# Altium schematic sheet Memory.SchDoc
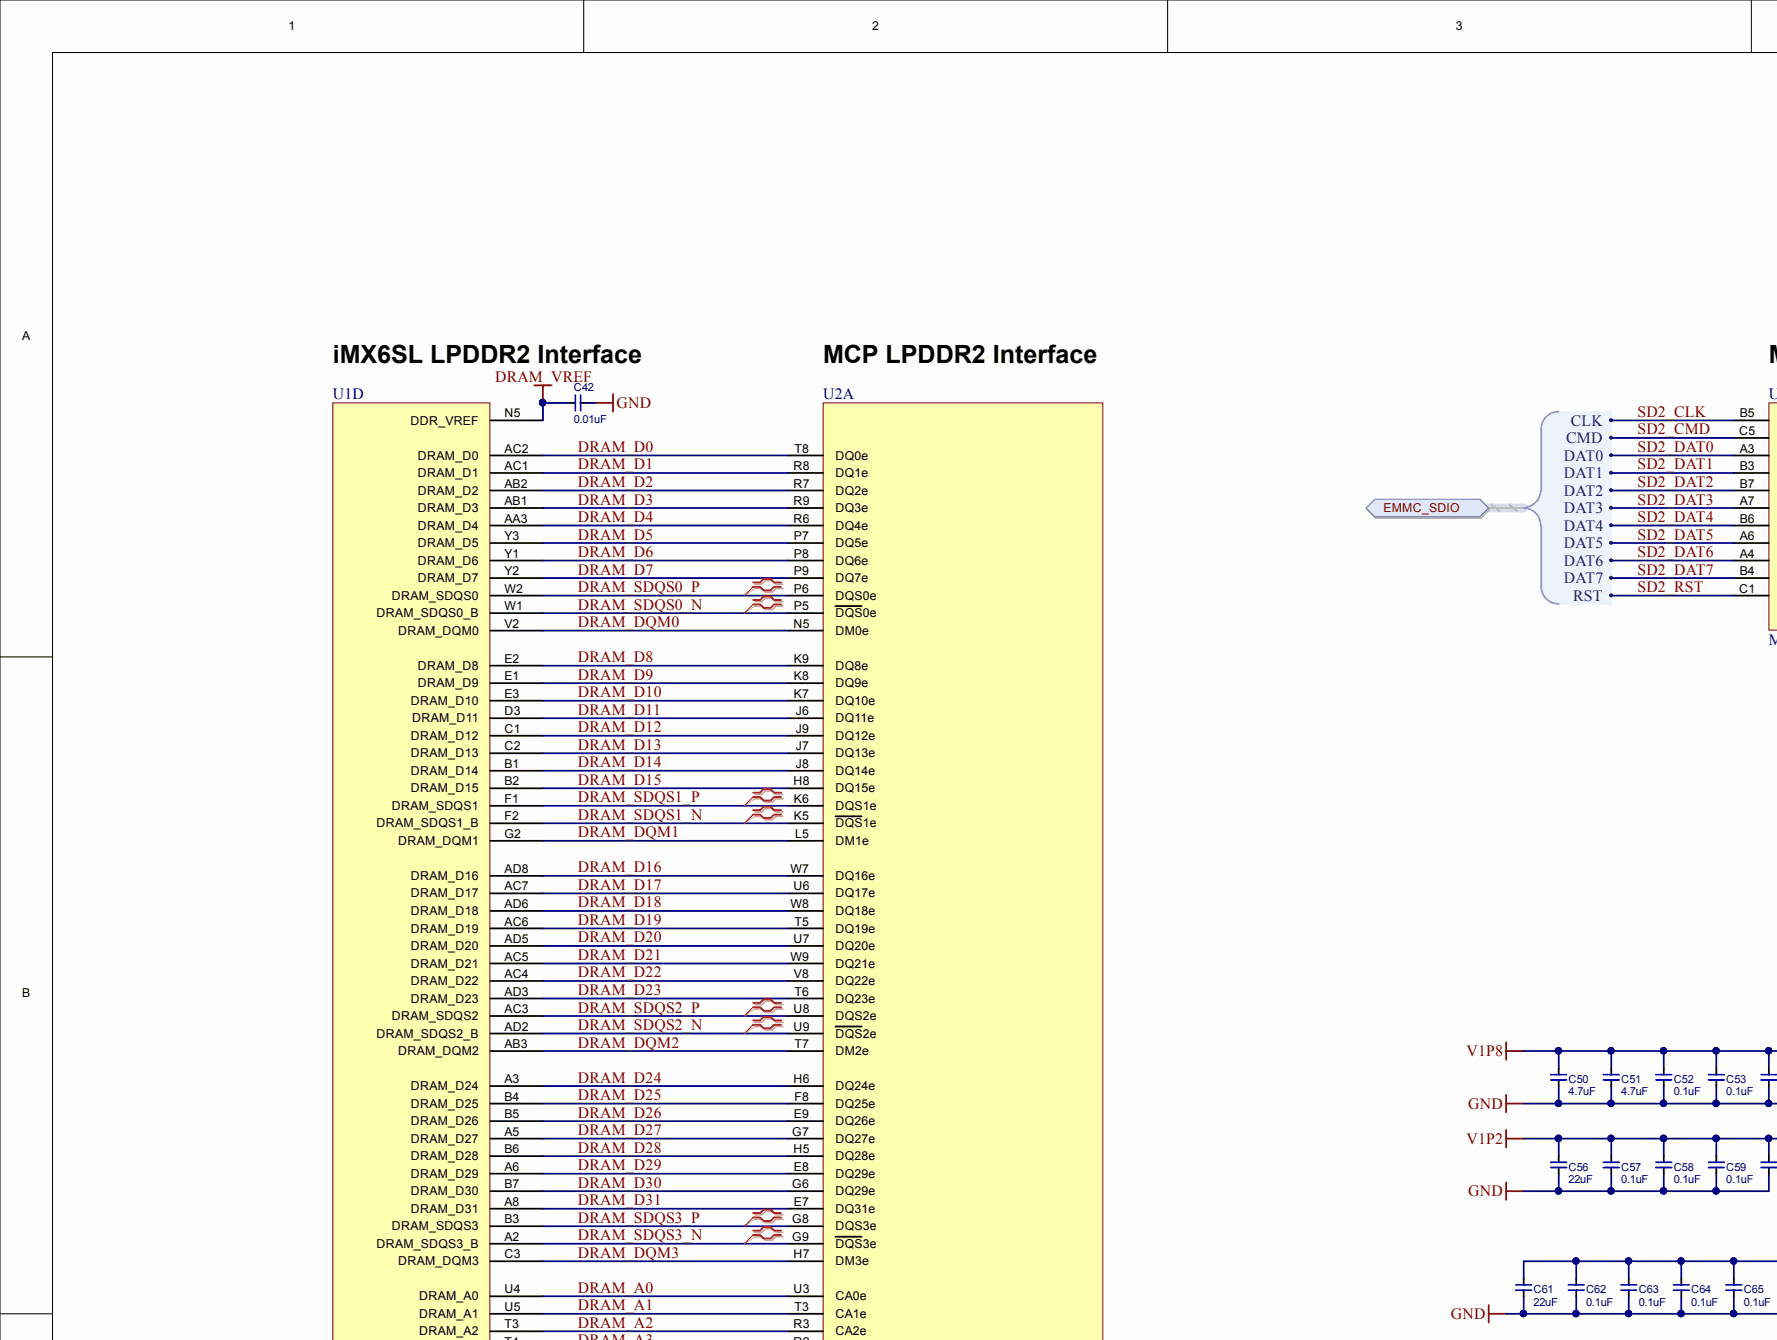
[diagram p0: Memory.SchDoc, top left]
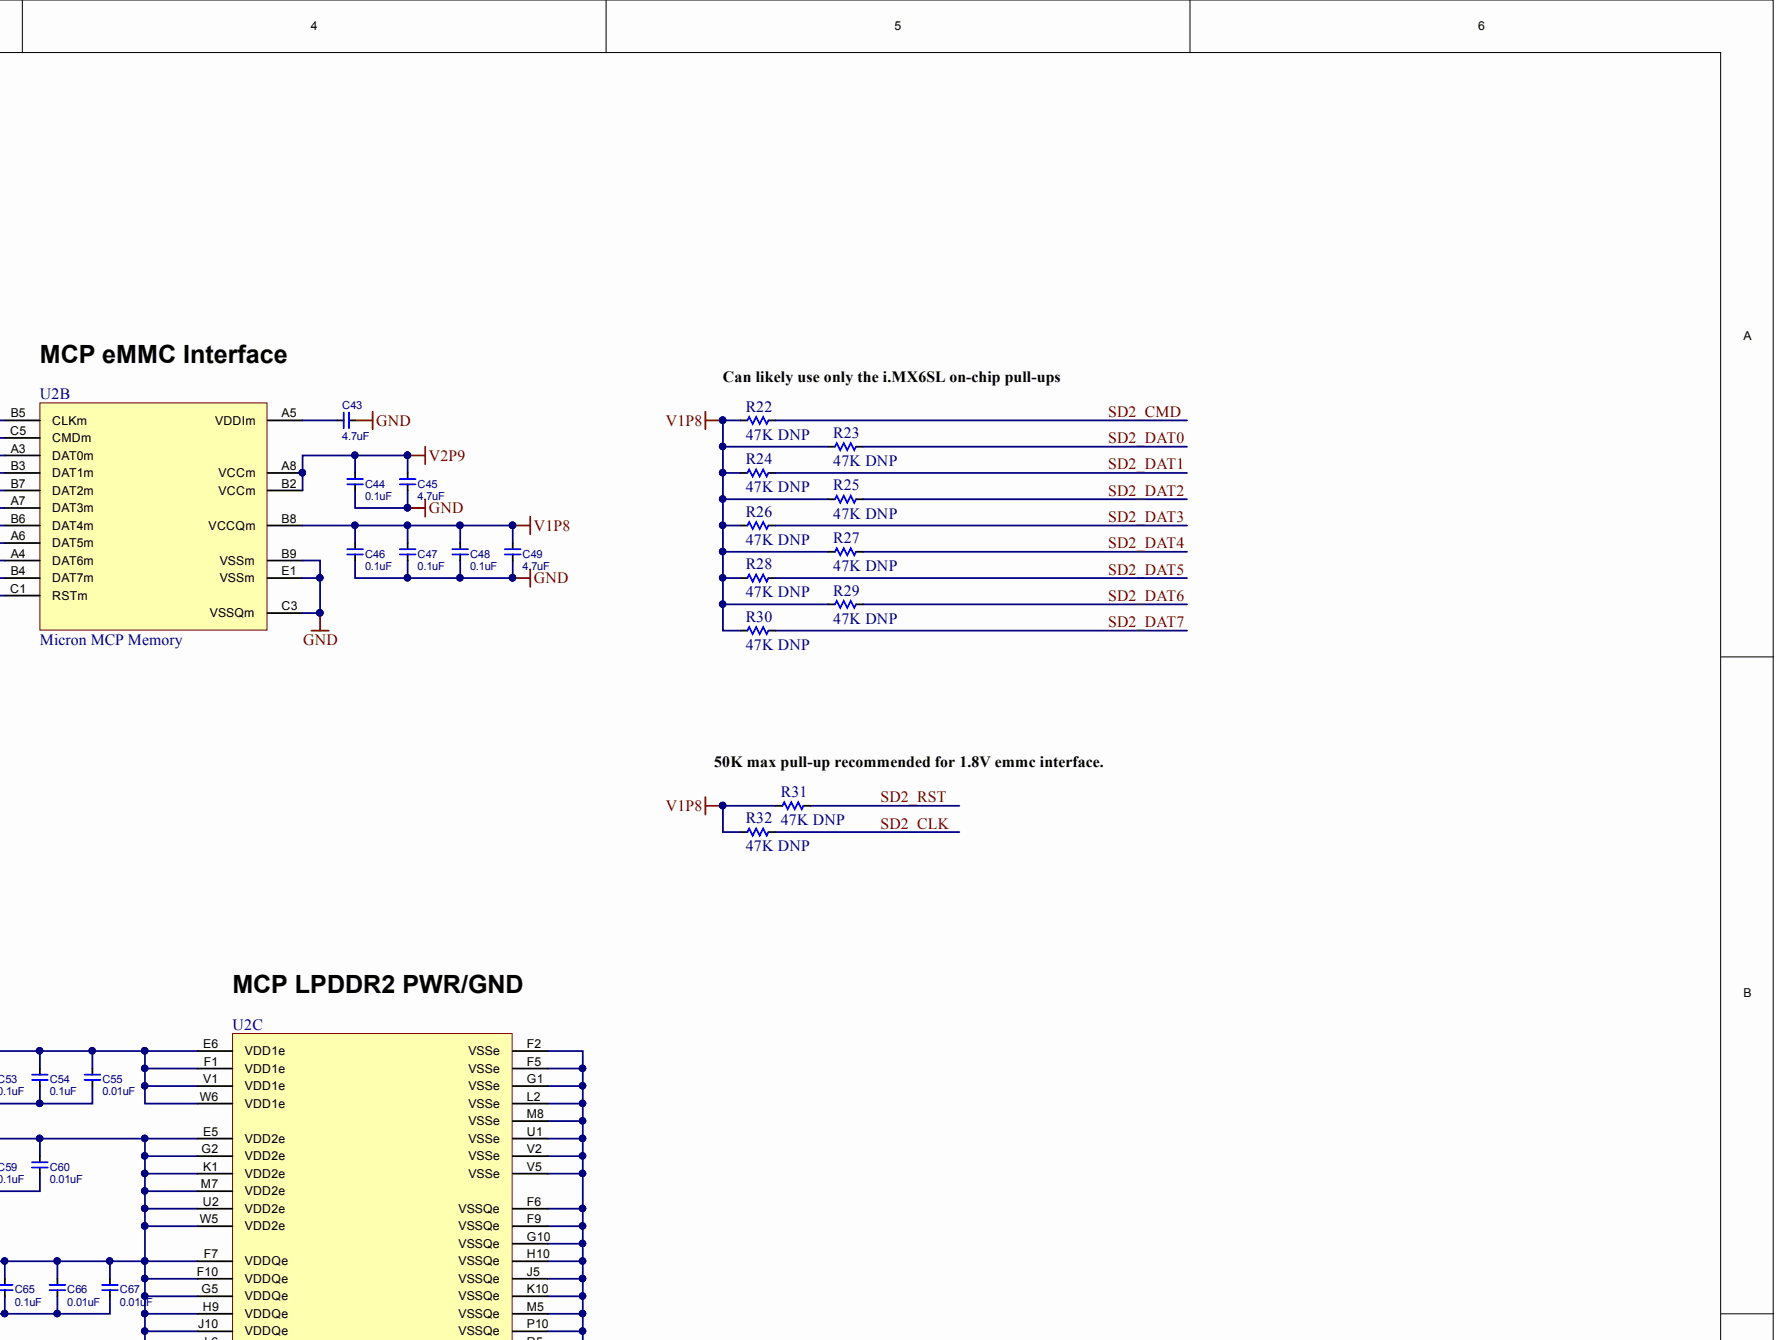
[diagram p1: Memory.SchDoc, top right]
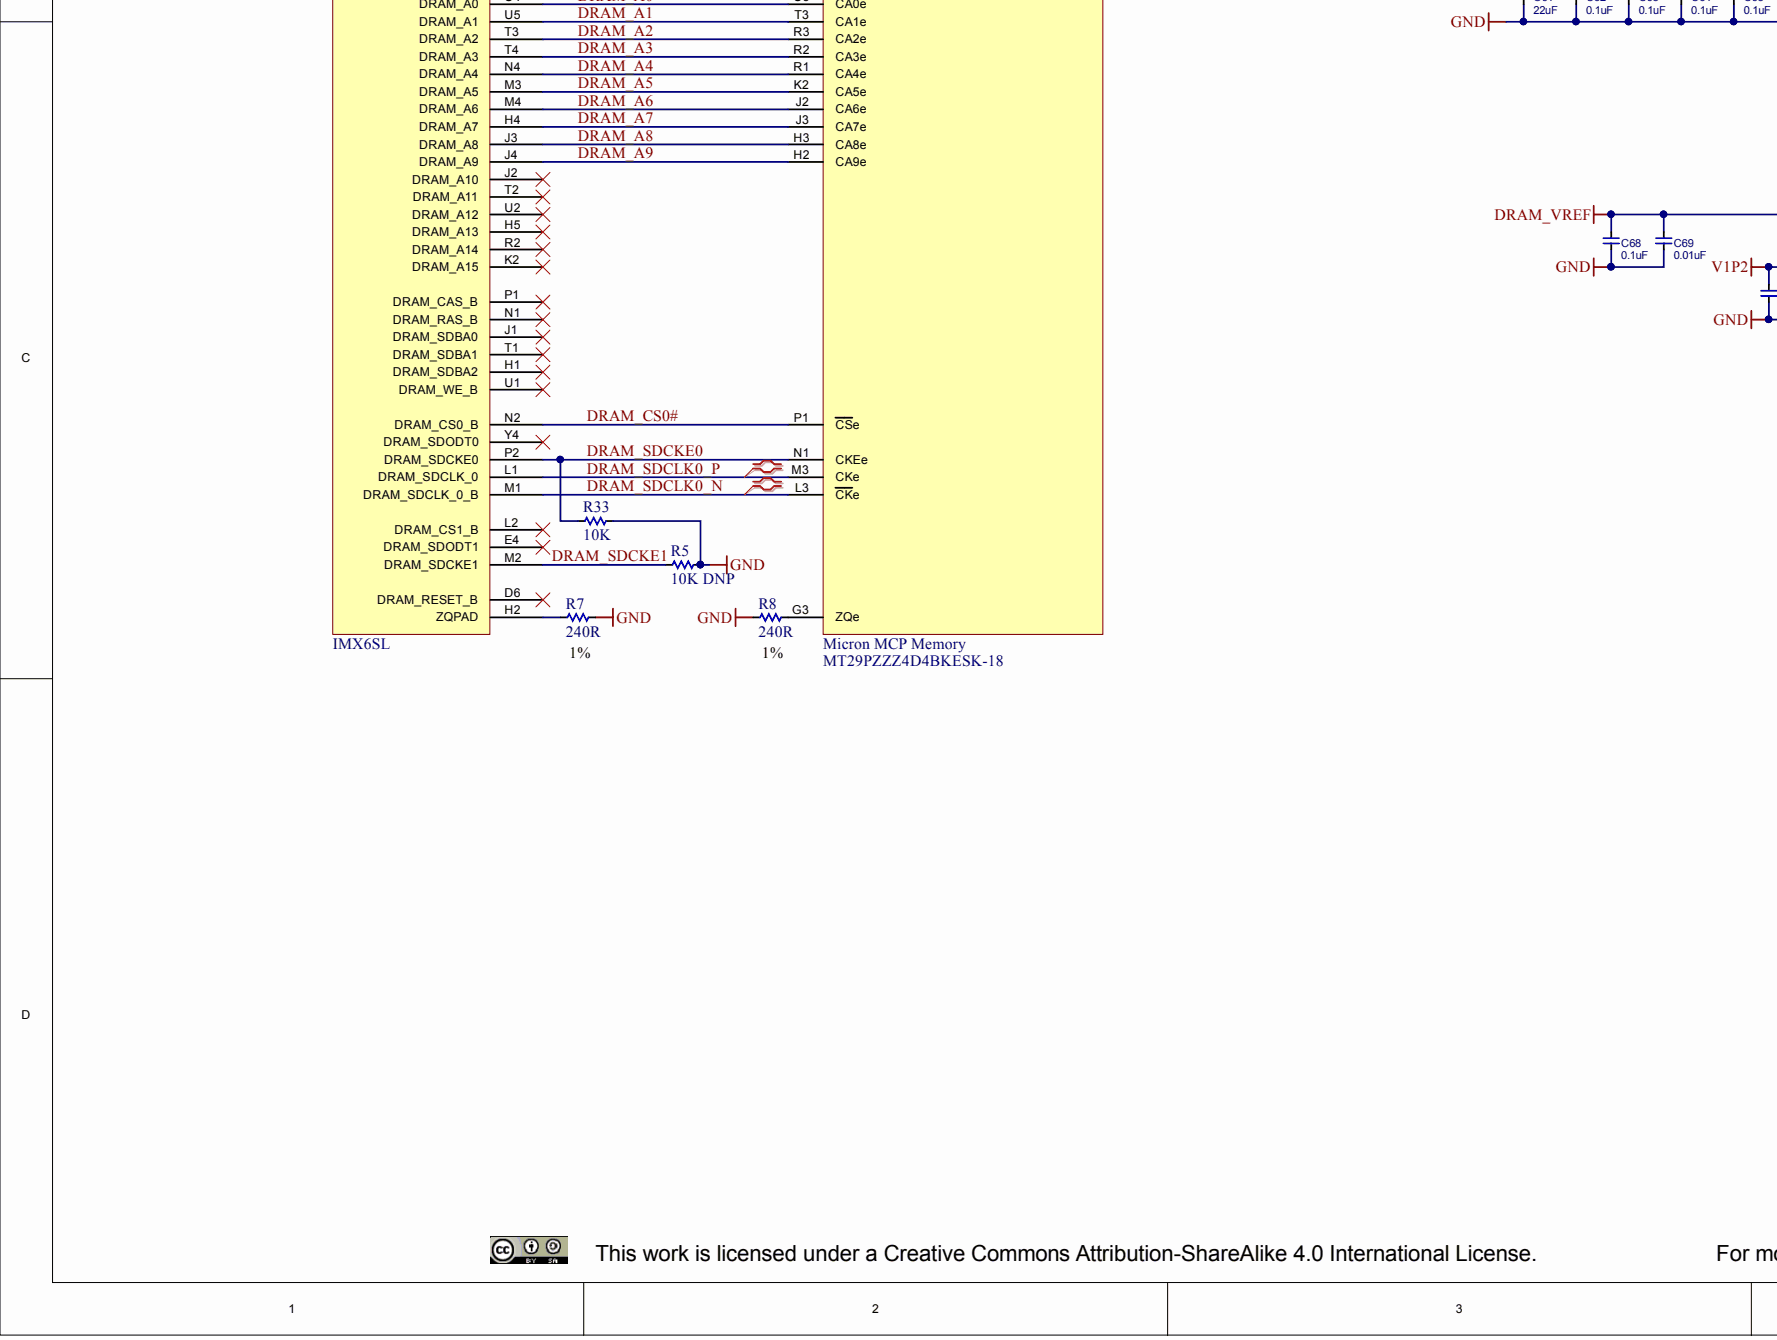
[diagram p2: Memory.SchDoc, bottom left]
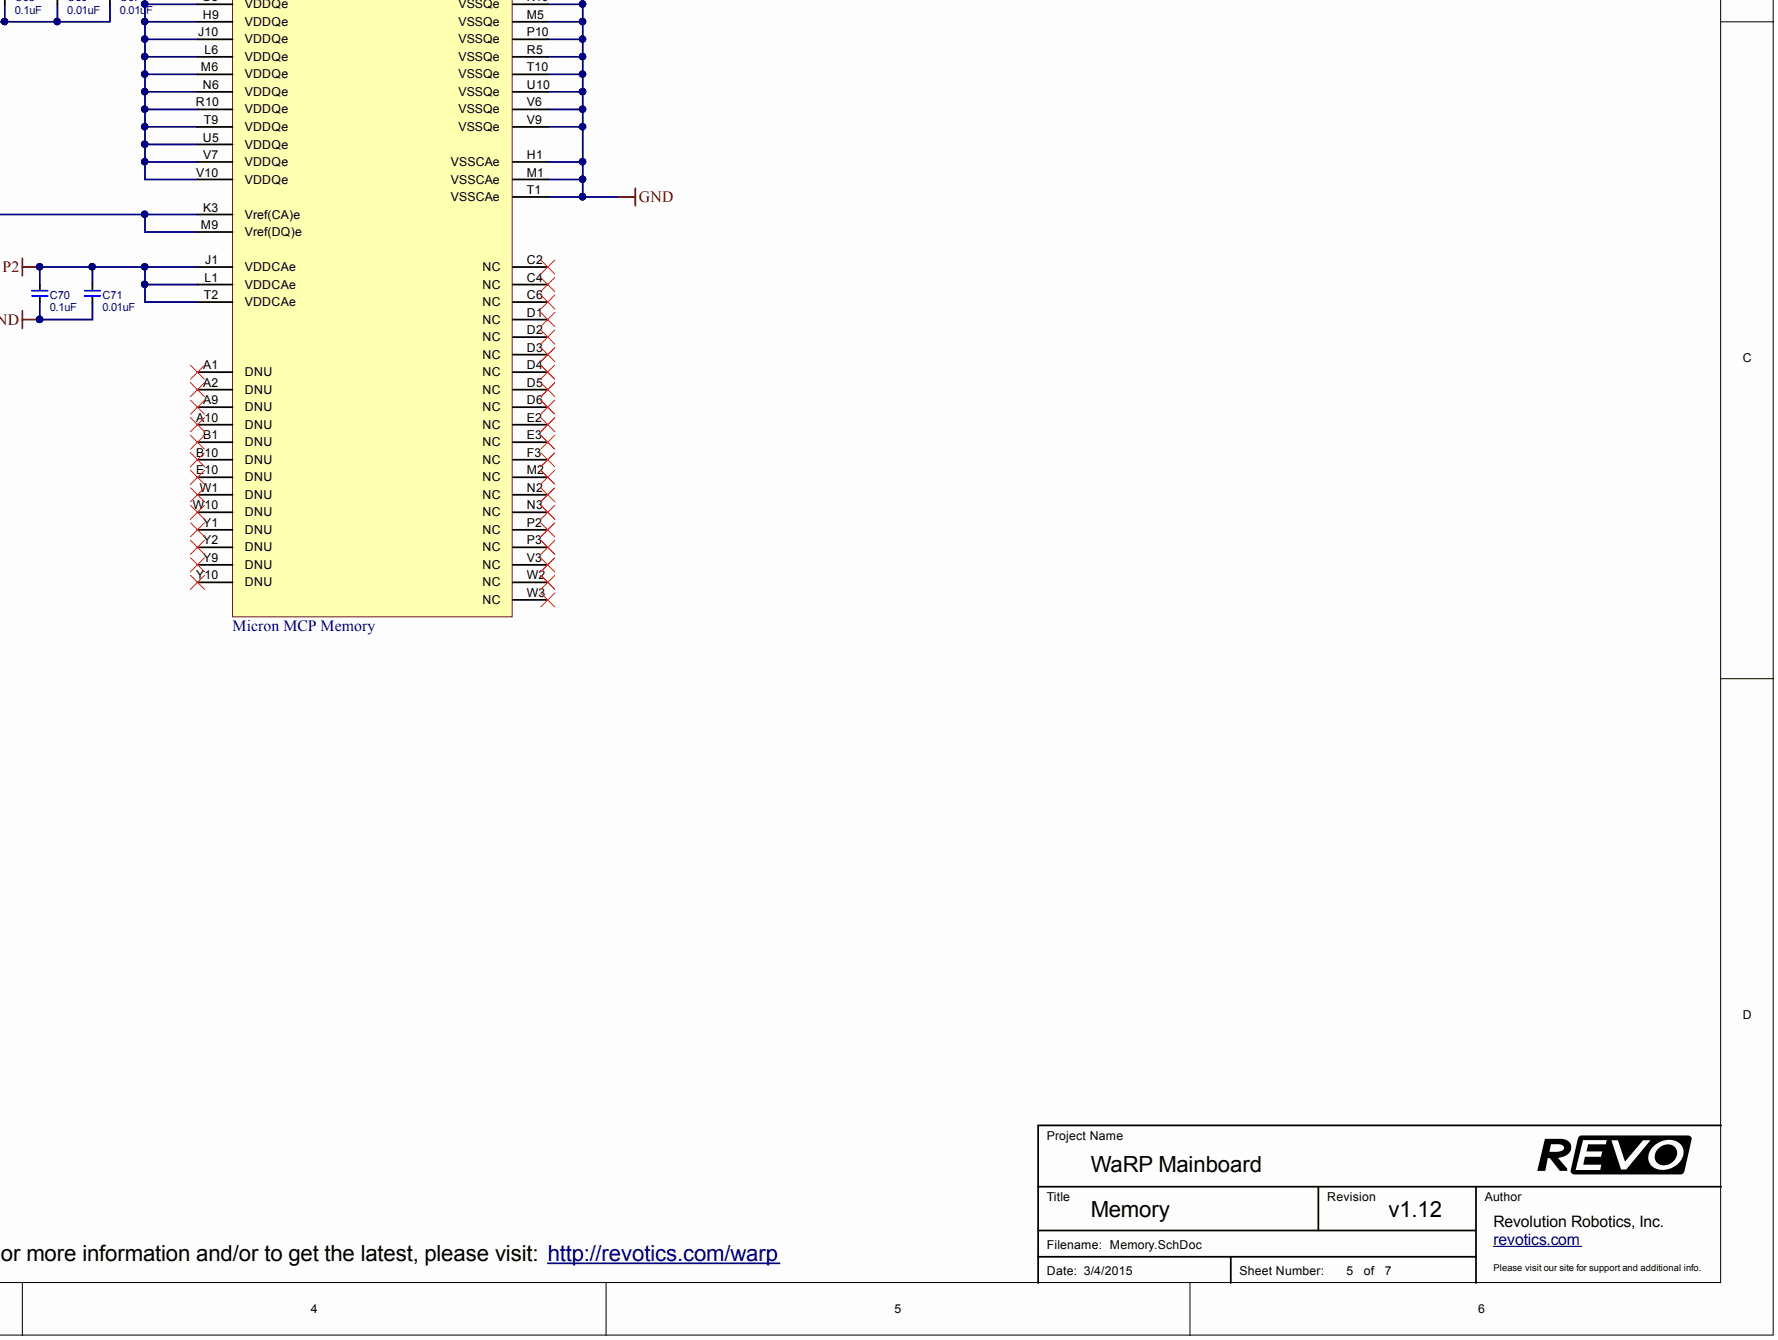
[diagram p3: Memory.SchDoc, bottom right]

## Parts
C42  value 0.01uF  [0201 (0603) Cap High Density]  (hidden comment: CapacitorSM)
C43  value 4.7uF  [0402 (1005) Cap High Density]  (hidden comment: CapacitorSM)
C44  value 0.1uF  [0201 (0603) Cap High Density]  (hidden comment: CapacitorSM)
C45  value 4.7uF  [0402 (1005) Cap High Density]  (hidden comment: CapacitorSM)
C46  value 0.1uF  [0201 (0603) Cap High Density]  (hidden comment: CapacitorSM)
C47  value 0.1uF  [0201 (0603) Cap High Density]  (hidden comment: CapacitorSM)
C48  value 0.1uF  [0201 (0603) Cap High Density]  (hidden comment: CapacitorSM)
C49  value 4.7uF  [0402 (1005) Cap High Density]  (hidden comment: CapacitorSM)
C50  value 4.7uF  [0402 (1005) Cap High Density]  (hidden comment: CapacitorSM)
C51  value 4.7uF  [0402 (1005) Cap High Density]  (hidden comment: CapacitorSM)
C52  value 0.1uF  [0201 (0603) Cap High Density]  (hidden comment: CapacitorSM)
C53  value 0.1uF  [0201 (0603) Cap High Density]  (hidden comment: CapacitorSM)
C54  value 0.1uF  [0201 (0603) Cap High Density]  (hidden comment: CapacitorSM)
C55  value 0.01uF  [0201 (0603) Cap High Density]  (hidden comment: CapacitorSM)
C56  value 22uF  [0603 (1608) Cap High Density]  (hidden comment: CapacitorSM)
C57  value 0.1uF  [0201 (0603) Cap High Density]  (hidden comment: CapacitorSM)
C58  value 0.1uF  [0201 (0603) Cap High Density]  (hidden comment: CapacitorSM)
C59  value 0.1uF  [0201 (0603) Cap High Density]  (hidden comment: CapacitorSM)
C60  value 0.01uF  [0201 (0603) Cap High Density]  (hidden comment: CapacitorSM)
C61  value 22uF  [0603 (1608) Cap High Density]  (hidden comment: CapacitorSM)
C62  value 0.1uF  [0201 (0603) Cap High Density]  (hidden comment: CapacitorSM)
C63  value 0.1uF  [0201 (0603) Cap High Density]  (hidden comment: CapacitorSM)
C64  value 0.1uF  [0201 (0603) Cap High Density]  (hidden comment: CapacitorSM)
C65  value 0.1uF  [0201 (0603) Cap High Density]  (hidden comment: CapacitorSM)
C66  value 0.01uF  [0201 (0603) Cap High Density]  (hidden comment: CapacitorSM)
C67  value 0.01uF  [0201 (0603) Cap High Density]  (hidden comment: CapacitorSM)
C68  value 0.1uF  [0201 (0603) Cap High Density]  (hidden comment: CapacitorSM)
C69  value 0.01uF  [0201 (0603) Cap High Density]  (hidden comment: CapacitorSM)
C70  value 0.1uF  [0201 (0603) Cap High Density]  (hidden comment: CapacitorSM)
C71  value 0.01uF  [0201 (0603) Cap High Density]  (hidden comment: CapacitorSM)
R5  value 10K DNP  [0201 (0603) Res High Density DNP]  (hidden comment: Resistor)
R7  value 240R  [0201 (0603) Res High Density]  (hidden comment: Resistor)
R8  value 240R  [0201 (0603) Res High Density]  (hidden comment: Resistor)
R22  value 47K DNP  [0201 (0603) Res High Density DNP]  (hidden comment: Resistor)
R23  value 47K DNP  [0201 (0603) Res High Density DNP]  (hidden comment: Resistor)
R24  value 47K DNP  [0201 (0603) Res High Density DNP]  (hidden comment: Resistor)
R25  value 47K DNP  [0201 (0603) Res High Density DNP]  (hidden comment: Resistor)
R26  value 47K DNP  [0201 (0603) Res High Density DNP]  (hidden comment: Resistor)
R27  value 47K DNP  [0201 (0603) Res High Density DNP]  (hidden comment: Resistor)
R28  value 47K DNP  [0201 (0603) Res High Density DNP]  (hidden comment: Resistor)
R29  value 47K DNP  [0201 (0603) Res High Density DNP]  (hidden comment: Resistor)
R30  value 47K DNP  [0201 (0603) Res High Density DNP]  (hidden comment: Resistor)
R31  value 47K DNP  [0201 (0603) Res High Density DNP]  (hidden comment: Resistor)
R32  value 47K DNP  [0201 (0603) Res High Density DNP]  (hidden comment: Resistor)
R33  value 10K  [0201 (0603) Res High Density]  (hidden comment: Resistor)
U1  IMX6SL  [BGA432C50P24X24_1300X1300X110]  (part D)
U2  Micron MCP Memory  Manufacturer: Micron  Part No.: MT29PZZZ4D4BKESK-18  [KMN5W000ZM]  (parts A,B,C)

## Ports
EMMC_SDIO, EMMC_SDIO.CLK, EMMC_SDIO.CMD, EMMC_SDIO.DAT0, EMMC_SDIO.DAT1, EMMC_SDIO.DAT2, EMMC_SDIO.DAT3, EMMC_SDIO.DAT4, EMMC_SDIO.DAT5, EMMC_SDIO.DAT6, EMMC_SDIO.DAT7, EMMC_SDIO.RST

## Nets
DRAM_VREF: C42.1 C68.1 C69.2 U1.N5(DDR_VREF) U2.K3(Vref(CA)e) U2.M9(Vref(DQ)e)
GND: C42.2 C43.2 C44.2 C45.1 C46.2 C47.2 C48.2 C49.1 C50.2 C51.2 C52.2 C53.2 C54.2 C55.1 C56.2 C57.2 C58.2 C59.2 C60.1 C61.2 C62.2 C63.2 C64.2 C65.2 C66.1 C67.1 C68.2 C69.1 C70.2 C71.1 R5.2 R7.1 R8.2 R33.2 U2.B9(VSSm) U2.C3(VSSQm) U2.E1(VSSm) U2.F2(VSSe) U2.F5(VSSe) U2.F6(VSSQe) U2.F9(VSSQe) U2.G1(VSSe) U2.G10(VSSQe) U2.H1(VSSCAe) U2.H10(VSSQe) U2.J5(VSSQe) U2.K10(VSSQe) U2.L2(VSSe) U2.M1(VSSCAe) U2.M5(VSSQe) U2.M8(VSSe) U2.P10(VSSQe) U2.R5(VSSQe) U2.T1(VSSCAe) U2.T10(VSSQe) U2.U1(VSSe) U2.U10(VSSQe) U2.V2(VSSe) U2.V5(VSSe) U2.V6(VSSQe) U2.V9(VSSQe)
V1P2: C56.1 C57.1 C58.1 C59.1 C60.2 C61.1 C62.1 C63.1 C64.1 C65.1 C66.2 C67.2 C70.1 C71.2 U2.E5(VDD2e) U2.F7(VDDQe) U2.F10(VDDQe) U2.G2(VDD2e) U2.G5(VDDQe) U2.H9(VDDQe) U2.J1(VDDCAe) U2.J10(VDDQe) U2.K1(VDD2e) U2.L1(VDDCAe) U2.L6(VDDQe) U2.M6(VDDQe) U2.M7(VDD2e) U2.N6(VDDQe) U2.R10(VDDQe) U2.T2(VDDCAe) U2.T9(VDDQe) U2.U2(VDD2e) U2.U5(VDDQe) U2.V7(VDDQe) U2.V10(VDDQe) U2.W5(VDD2e)
V1P8: C46.1 C47.1 C48.1 C49.2 C50.1 C51.1 C52.1 C53.1 C54.1 C55.2 R22.2 R23.2 R24.2 R25.2 R26.2 R27.2 R28.2 R29.2 R30.2 R31.2 R32.2 U2.B8(VCCQm) U2.E6(VDD1e) U2.F1(VDD1e) U2.V1(VDD1e) U2.W6(VDD1e)
V2P9: C44.1 C45.2 U2.A8(VCCm) U2.B2(VCCm)
DRAM_A0: U1.U4(DRAM_A0) U2.U3(CA0e)
DRAM_A1: U1.U5(DRAM_A1) U2.T3(CA1e)
DRAM_A2: U1.T3(DRAM_A2) U2.R3(CA2e)
DRAM_A3: U1.T4(DRAM_A3) U2.R2(CA3e)
DRAM_A4: U1.N4(DRAM_A4) U2.R1(CA4e)
DRAM_A5: U1.M3(DRAM_A5) U2.K2(CA5e)
DRAM_A6: U1.M4(DRAM_A6) U2.J2(CA6e)
DRAM_A7: U1.H4(DRAM_A7) U2.J3(CA7e)
DRAM_A8: U1.J3(DRAM_A8) U2.H3(CA8e)
DRAM_A9: U1.J4(DRAM_A9) U2.H2(CA9e)
DRAM_CS0#: U1.N2(DRAM_CS0_B) U2.P1(CSe)
DRAM_D0: U1.AC2(DRAM_D0) U2.T8(DQ0e)
DRAM_D1: U1.AC1(DRAM_D1) U2.R8(DQ1e)
DRAM_D2: U1.AB2(DRAM_D2) U2.R7(DQ2e)
DRAM_D3: U1.AB1(DRAM_D3) U2.R9(DQ3e)
DRAM_D4: U1.AA3(DRAM_D4) U2.R6(DQ4e)
DRAM_D5: U1.Y3(DRAM_D5) U2.P7(DQ5e)
DRAM_D6: U1.Y1(DRAM_D6) U2.P8(DQ6e)
DRAM_D7: U1.Y2(DRAM_D7) U2.P9(DQ7e)
DRAM_D8: U1.E2(DRAM_D8) U2.K9(DQ8e)
DRAM_D9: U1.E1(DRAM_D9) U2.K8(DQ9e)
DRAM_D10: U1.E3(DRAM_D10) U2.K7(DQ10e)
DRAM_D11: U1.D3(DRAM_D11) U2.J6(DQ11e)
DRAM_D12: U1.C1(DRAM_D12) U2.J9(DQ12e)
DRAM_D13: U1.C2(DRAM_D13) U2.J7(DQ13e)
DRAM_D14: U1.B1(DRAM_D14) U2.J8(DQ14e)
DRAM_D15: U1.B2(DRAM_D15) U2.H8(DQ15e)
DRAM_D16: U1.AD8(DRAM_D16) U2.W7(DQ16e)
DRAM_D17: U1.AC7(DRAM_D17) U2.U6(DQ17e)
DRAM_D18: U1.AD6(DRAM_D18) U2.W8(DQ18e)
DRAM_D19: U1.AC6(DRAM_D19) U2.T5(DQ19e)
DRAM_D20: U1.AD5(DRAM_D20) U2.U7(DQ20e)
DRAM_D21: U1.AC5(DRAM_D21) U2.W9(DQ21e)
DRAM_D22: U1.AC4(DRAM_D22) U2.V8(DQ22e)
DRAM_D23: U1.AD3(DRAM_D23) U2.T6(DQ23e)
DRAM_D24: U1.A3(DRAM_D24) U2.H6(DQ24e)
DRAM_D25: U1.B4(DRAM_D25) U2.F8(DQ25e)
DRAM_D26: U1.B5(DRAM_D26) U2.E9(DQ26e)
DRAM_D27: U1.A5(DRAM_D27) U2.G7(DQ27e)
DRAM_D28: U1.B6(DRAM_D28) U2.H5(DQ28e)
DRAM_D29: U1.A6(DRAM_D29) U2.E8(DQ29e)
DRAM_D30: U1.B7(DRAM_D30) U2.G6(DQ29e)
DRAM_D31: U1.A8(DRAM_D31) U2.E7(DQ31e)
DRAM_DQM0: U1.V2(DRAM_DQM0) U2.N5(DM0e)
DRAM_DQM1: U1.G2(DRAM_DQM1) U2.L5(DM1e)
DRAM_DQM2: U1.AB3(DRAM_DQM2) U2.T7(DM2e)
DRAM_DQM3: U1.C3(DRAM_DQM3) U2.H7(DM3e)
DRAM_SDCKE0: R33.1 U1.P2(DRAM_SDCKE0) U2.N1(CKEe)
DRAM_SDCKE1: R5.1 U1.M2(DRAM_SDCKE1)
DRAM_SDCLK0_N: U1.M1(DRAM_SDCLK_0_B) U2.L3(CKe)
DRAM_SDCLK0_P: U1.L1(DRAM_SDCLK_0) U2.M3(CKe)
DRAM_SDQS0_N: U1.W1(DRAM_SDQS0_B) U2.P5(DQS0e)
DRAM_SDQS0_P: U1.W2(DRAM_SDQS0) U2.P6(DQS0e)
DRAM_SDQS1_N: U1.F2(DRAM_SDQS1_B) U2.K5(DQS1e)
DRAM_SDQS1_P: U1.F1(DRAM_SDQS1) U2.K6(DQS1e)
DRAM_SDQS2_N: U1.AD2(DRAM_SDQS2_B) U2.U9(DQS2e)
DRAM_SDQS2_P: U1.AC3(DRAM_SDQS2) U2.U8(DQS2e)
DRAM_SDQS3_N: U1.A2(DRAM_SDQS3_B) U2.G9(DQS3e)
DRAM_SDQS3_P: U1.B3(DRAM_SDQS3) U2.G8(DQS3e)
SD2_CLK: R32.1 U2.B5(CLKm) ; port EMMC_SDIO
SD2_CMD: R22.1 U2.C5(CMDm) ; port EMMC_SDIO
SD2_DAT0: R23.1 U2.A3(DAT0m) ; port EMMC_SDIO
SD2_DAT1: R24.1 U2.B3(DAT1m) ; port EMMC_SDIO
SD2_DAT2: R25.1 U2.B7(DAT2m) ; port EMMC_SDIO
SD2_DAT3: R26.1 U2.A7(DAT3m) ; port EMMC_SDIO
SD2_DAT4: R27.1 U2.B6(DAT4m) ; port EMMC_SDIO
SD2_DAT5: R28.1 U2.A6(DAT5m) ; port EMMC_SDIO
SD2_DAT6: R29.1 U2.A4(DAT6m) ; port EMMC_SDIO
SD2_DAT7: R30.1 U2.B4(DAT7m) ; port EMMC_SDIO
SD2_RST: R31.1 U2.C1(RSTm) ; port EMMC_SDIO
NetC43_1: C43.1 U2.A5(VDDIm)
NetR7_2: R7.2 U1.H2(ZQPAD)
NetR8_1: R8.1 U2.G3(ZQe)

Not connected: U1 D6(DRAM_RESET_B) E4(DRAM_SDODT1) H1(DRAM_SDBA2) H5(DRAM_A13) J1(DRAM_SDBA0) J2(DRAM_A10) K2(DRAM_A15) L2(DRAM_CS1_B) N1(DRAM_RAS_B) P1(DRAM_CAS_B) R2(DRAM_A14) T1(DRAM_SDBA1) T2(DRAM_A11) U1(DRAM_WE_B) U2(DRAM_A12) Y4(DRAM_SDODT0); U2 A1(DNU) A2(DNU) A9(DNU) A10(DNU) B1(DNU) B10(DNU) C2(NC) C4(NC) C6(NC) D1(NC) D2(NC) D3(NC) D4(NC) D5(NC) D6(NC) E2(NC) E3(NC) E10(DNU) F3(NC) M2(NC) N2(NC) N3(NC) P2(NC) P3(NC) V3(NC) W1(DNU) W2(NC) W3(NC) W10(DNU) Y1(DNU) Y2(DNU) Y9(DNU) Y10(DNU)

## Library data of the parts
C42 C55 C60 C66 C67 C69 C71 = CapacitorSM: Capacitor; Manufacturer: Murata; Part No.: GRM033R70J103KA01D; Vendor: Mouser; Voltage: 6.3; Component Kind: Standard; Library Name: Passive.SchLib
C43 C45 C49-C51 = CapacitorSM: Capacitor; Manufacturer: TDK; Part No.: C1005X5R0J475M050BC; Vendor: Digikey; Voltage: 6.3; Component Kind: Standard; Library Name: Passive.SchLib
C44 C46-C48 C52-C54 C57-C59 C62-C65 C68 C70 = CapacitorSM: Capacitor; Manufacturer: Murata; Part No.: GRM033R60J104ME19D; Vendor: Digikey; Voltage: 6.3; Component Kind: Standard; Library Name: Passive.SchLib
C56 C61 = CapacitorSM: Capacitor; Manufacturer: Murata; Part No.: GRM188C80G226MEA0D; Vendor: Mouser; Component Kind: Standard; Library Name: Passive.SchLib
R5 R33 = Resistor: Manufacturer: Vishay / Dale; Part No.: CRCW020110K0FNED; Part No. Ref: CRCW[PACKAGE][VALUE]; Vendor: Digikey; Component Kind: Standard; Description: Resistor; Library Name: Passive.SchLib; Simulation: RES
R7 R8 = Resistor: Manufacturer: Vishay / Dale; Part No.: CRCW0201240RFKED; Part No. Ref: CRCW[PACKAGE][VALUE]; Vendor: Digikey; Component Kind: Standard; Description: Resistor; Library Name: Passive.SchLib; Simulation: RES
R22-R32 = Resistor: Manufacturer: Vishay / Dale; Part No.: CRCW020147K0FKED; Part No. Ref: CRCW[PACKAGE][VALUE]; Vendor: Digikey; Component Kind: Standard; Description: Resistor; Library Name: Passive.SchLib; Simulation: RES
U1 = IMX6SL: Freescale iMX6 SoloLite; Part No.: MCIMX6L8DVN10AB; Vendor: Verical; Component Kind: Standard; ComponentLink1Description: Datasheet; ComponentLink2Description: Freescale Webpage; ComponentLink2URL: http://www.freescale.com/webapp/sps/site/prod_summary.jsp?code=i.MX6SL; Library Name: iMX6.SchLib; Manufacturer: Freescale; Supplier 1: Newark; Supplier Part Number 1: 31W8709; ComponentLink1URL: R:\Hardware\Part Datasheets\Freescale\IMX6SLCEC.pdf
U2 = Samsung MCP Memory: Samsung LPDDR2 (512MB) + eMMC MCP (4GB); Component Kind: Standard; Library Name: SamsungMemory.SchLib
